# Altium schematic sheet 12-5V Buck Converter.SchDoc
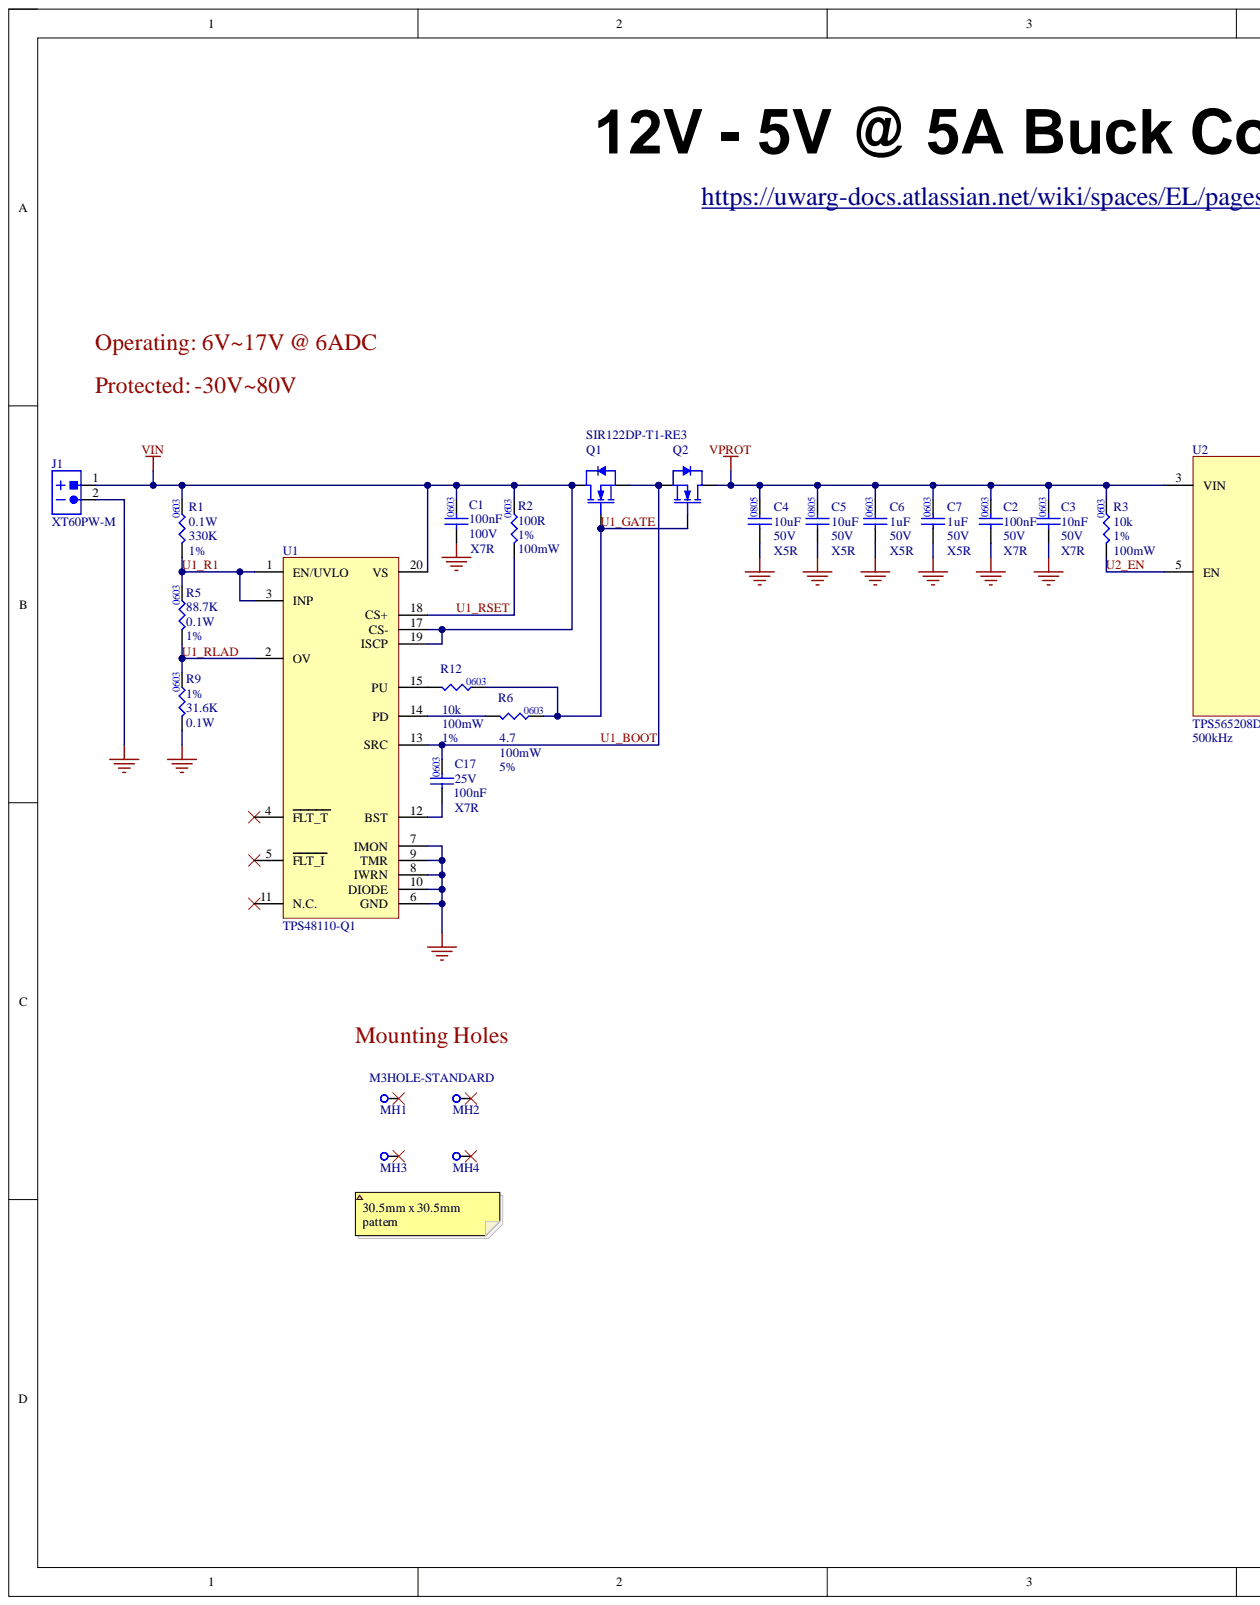
[diagram p0: 12-5V Buck Converter.SchDoc, left]
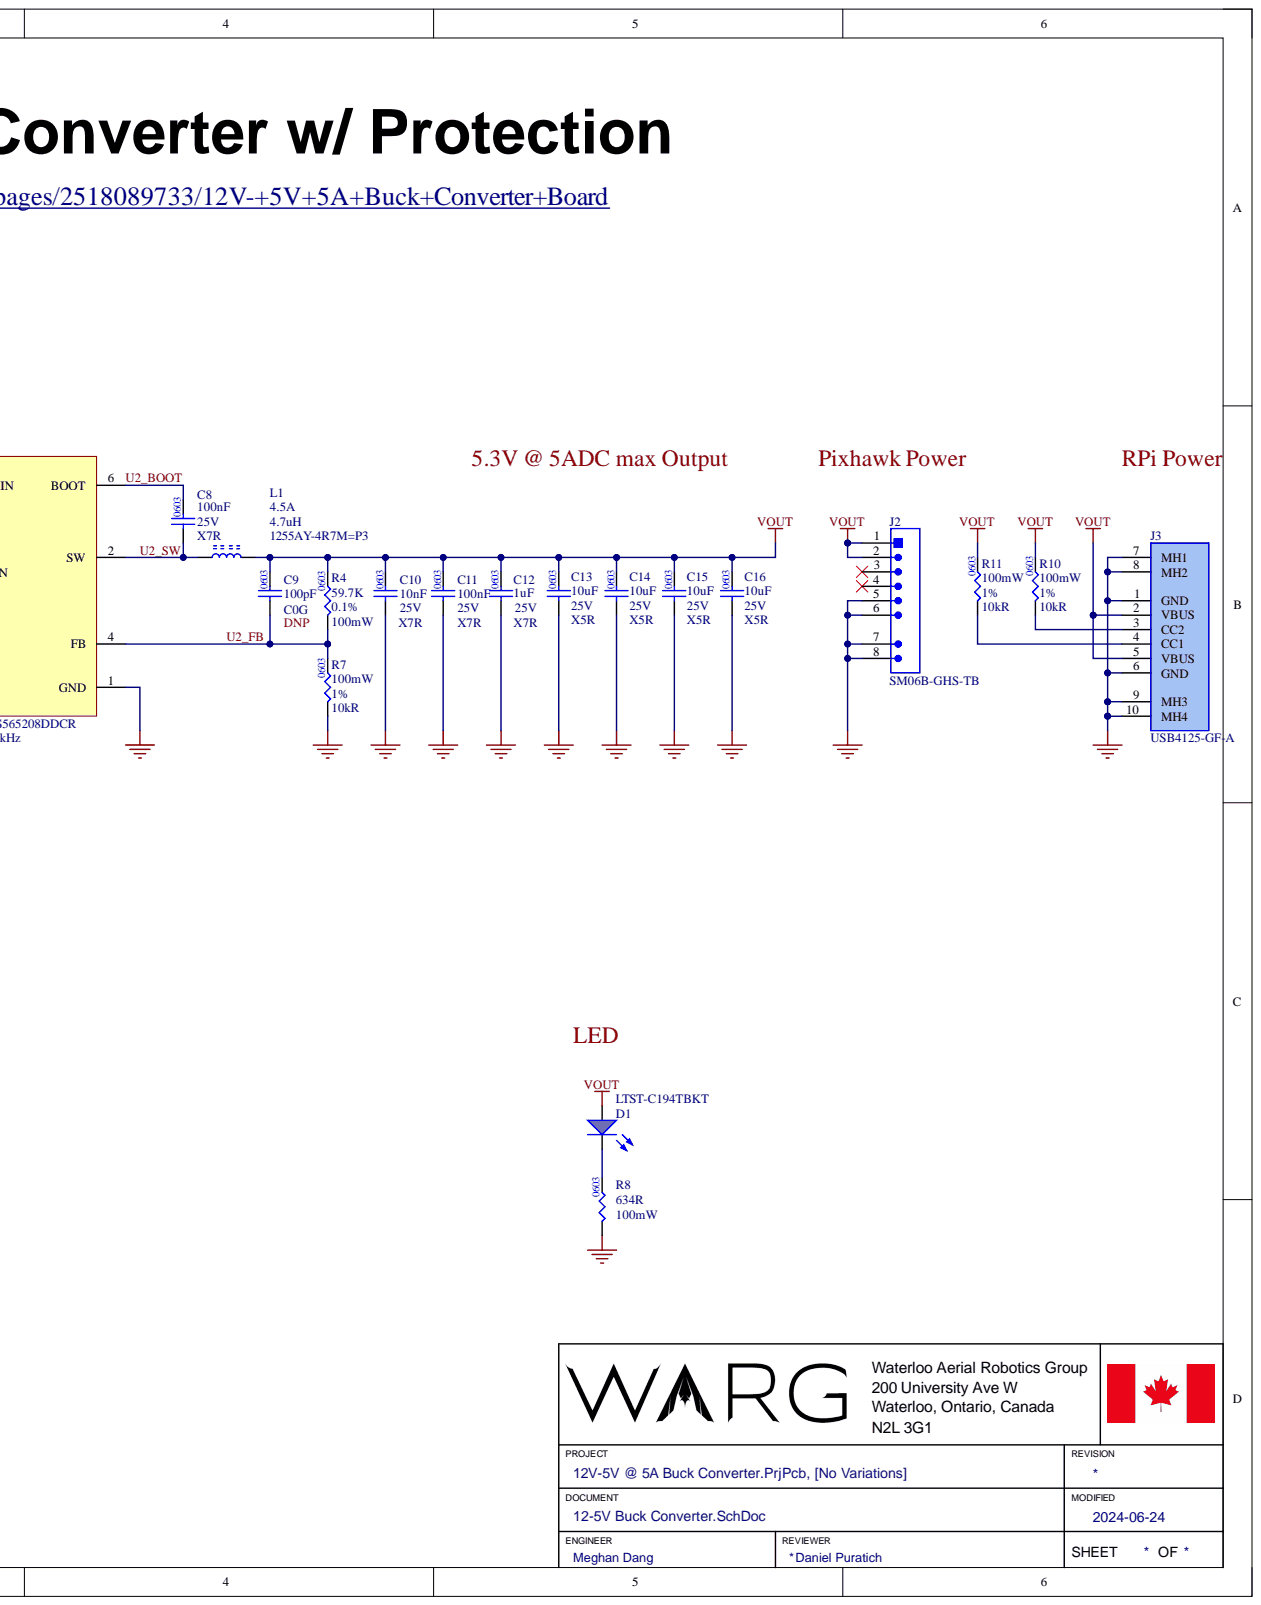
[diagram p1: 12-5V Buck Converter.SchDoc, right]

## Parts
C1  value 100nF  Case/Package: 0603  Dielectric: X7R  Rated DC Voltage (URdc): 100V  [.CAP_0603-Footprint-1]  (hidden comment: CC0603KRX7R0BB104)
C2  Capacitance: 100nF  Dielectric: X7R  Voltage Rating: 50V  [.CAP_0603-Footprint-1]  (hidden comment: CGA3E2X7R1H104K080AA)
C3  Capacitance: 10nF  Case Code (Imperial): 0603  Dielectric: X7R  Voltage: 50V  [.CAP_0603-Footprint-1]  (hidden comment: GCM188R71H103KA37D)
C4  value 10uF  Capacitance: 10uF  Dielectric: X5R  Package: 0805  Voltage: 50V  [.CAP_0805-Footprint-1]  (hidden comment: GRM21BR61H106KE43L)
C5  value 10uF  Capacitance: 10uF  Dielectric: X5R  Package: 0805  Voltage: 50V  [.CAP_0805-Footprint-1]  (hidden comment: GRM21BR61H106KE43L)
C6  Capacitance: 1uF  Case/Package: 0603  Dielectric: X5R  Voltage Rating: 50V  [.CAP_0603-Footprint-1]  (hidden comment: GCM188R71E102KA37D)
C7  Capacitance: 1uF  Case/Package: 0603  Dielectric: X5R  Voltage Rating: 50V  [.CAP_0603-Footprint-1]  (hidden comment: GCM188R71E102KA37D)
C8  Capacitance: 100nF  Case/Package: 0603  Dielectric: X7R  Voltage Rating: 25V  [CAP0603]  (hidden comment: CL10B104KA8NNNC)
C9  value 100pF  Case/Package: 0603  Temperature Characteristics Code: C0G  [.CAP_0603-Footprint-1]  (hidden comment: GCM1885C2A101JA16D)
C10  Capacitance: 10nF  Package: 0603  Temperature Coefficient: X7R  Voltage Rating: 25V  [.CAP_0603-Footprint-1]  (hidden comment: CC0603KRX7R8BB103)
C11  Capacitance: 100nF  Case/Package: 0603  Dielectric: X7R  Voltage Rating: 25V  [.CAP_0603-Footprint-1]  (hidden comment: CL10B104KA8NNNC)
C12  Capacitance: 1uF  Temperature Coefficient: X7R  Voltage Rating: 25V  [.CAP_0603-Footprint-1]  (hidden comment: GCM188R71E105KA64D)
C13  value 10uF  Case/Package: 0603  Temperature Coefficient: X5R  Voltage Rating: 25V  [.CAP_0603-Footprint-1]  (hidden comment: GRM188R61E106KA73J)
C14  value 10uF  Case/Package: 0603  Temperature Coefficient: X5R  Voltage Rating: 25V  [.CAP_0603-Footprint-1]  (hidden comment: GRM188R61E106KA73J)
C15  value 10uF  Case/Package: 0603  Temperature Coefficient: X5R  Voltage Rating: 25V  [.CAP_0603-Footprint-1]  (hidden comment: GRM188R61E106KA73J)
C16  value 10uF  Case/Package: 0603  Temperature Coefficient: X5R  Voltage Rating: 25V  [.CAP_0603-Footprint-1]  (hidden comment: GRM188R61E106KA73J)
C17  Capacitance: 100nF  Case/Package: 0603  Dielectric: X7R  Voltage Rating: 25V  [.CAP_0603-Footprint-1]  (hidden comment: CL10B104KA8NNNC)
D1  LTST-C194TBKT  [LTST-C194TBKT-Footprint-1]
J1  XT60PW-M  [XT60PW-M-Footprint-1]
J2  SM06B-GHS-TB  [SM06B-GHS-TB-Footprint-1]
J3  USB4125-GF-A  [USB4125-GF-A-Footprint-1]
L1  1255AY-4R7M=P3  Current Rating: 4.5A  Inductance: 4.7uH  [FP-DG6045C-MFG]
MH1  M3HOLE-STANDARD  [M3HOLE-STANDARD-Footprint-1]
MH2  M3HOLE-STANDARD  [M3HOLE-STANDARD-Footprint-1]
MH3  M3HOLE-STANDARD  [M3HOLE-STANDARD-Footprint-1]
MH4  M3HOLE-STANDARD  [M3HOLE-STANDARD-Footprint-1]
Q1  SIR122DP-T1-RE3  [SIR122DP-T1-RE3-Footprint-1]
Q2  SIR122DP-T1-RE3  [SIR122DP-T1-RE3-Footprint-1]
R1  Package: 0603  Power: 0.1W  Resistance: 330K  Tolerance: 1%  [.RES_0603-Footprint-1]  (hidden comment: RC0603FR-07330KL)
R2  Case Code (Imperial): 0603  Max Power Dissipation: 100mW  Resistance: 100R  Resistance Tolerance: 1%  [.RES_0603-Footprint-1]  (hidden comment: RC0603FR-07100RL)
R3  Rated Power Dissipation (P): 100mW  Resistance: 10k  Tolerance: 1%  [RES0603]  (hidden comment: AC0603FR-1310KL)
R4  Power Rating: 100mW  Resistance: 59.7K  Tolerance: 0.1%  [.RES_0603-Footprint-1]  (hidden comment: RT0603BRD0759K7L)
R5  Package: 0603  Power: 0.1W  Resistance: 88.7K  Tolerance: 1%  [.RES_0603-Footprint-1]  (hidden comment: RC0603FR-0788K7L)
R6  Package: 0603  Power: 100mW  Resistance: 4.7  [.RES_0603-Footprint-1]  (hidden comment: RC0603JR-074R7L)
R7  Rated Power Dissipation (P): 100mW  Resistance: 10kR  Tolerance: 1%  [.RES_0603-Footprint-1]  (hidden comment: AC0603FR-1310KL)
R8  value 634R  Case/Package: 0603  Power: 100mW  [.RES_0603-Footprint-1]  (hidden comment: RC0603FR-07634RL)
R9  Package: 0603  Power: 0.1W  Resistance: 31.6K  Tolerance: 1%  [.RES_0603-Footprint-1]  (hidden comment: RC0603FR-0731K6L)
R10  Rated Power Dissipation (P): 100mW  Resistance: 10kR  Tolerance: 1%  [.RES_0603-Footprint-1]  (hidden comment: AC0603FR-1310KL)
R11  Rated Power Dissipation (P): 100mW  Resistance: 10kR  Tolerance: 1%  [.RES_0603-Footprint-1]  (hidden comment: AC0603FR-1310KL)
R12  Resistance: 10k  [RES0603]  (hidden comment: AC0603FR-1310KL)
U1  TPS48110-Q1  [TPS48110-Q1-Footprint-1]
U2  TPS565208DDCR  Switching Frequency: 500kHz  [TPS565208DDCR-Footprint-1]

## Nets
GND: C1.1 C2.1 C3.1 C4.1 C5.1 C6.1 C7.1 C10.1 C11.1 C12.1 C13.1 C14.1 C15.1 C16.1 J1.2 J2.5 J2.6 J2.7 J2.8 J3.1(GND) J3.6(GND) J3.7(MH1) J3.8(MH2) J3.9(MH3) J3.10(MH4) R7.1 R8.1 R9.1 U1.6(GND) U1.7(IMON) U1.8(IWRN) U1.9(TMR) U1.10(DIODE) U2.1(GND)
VIN: C1.2 J1.1 Q1.D R1.2 R2.2 U1.17(CS-) U1.19(ISCP) U1.20(VS)
VOUT: C9.2 C10.2 C11.2 C12.2 C13.2 C14.2 C15.2 C16.2 D1.1 J2.1 J2.2 J3.2(VBUS) J3.5(VBUS) L1.2 R4.2 R10.2 R11.2
VPROT: C2.2 C3.2 C4.2 C5.2 C6.2 C7.2 Q2.D R3.2 U2.3(VIN)
U1_BOOT: C17.2 Q1.S Q2.S U1.13(SRC)
U1_GATE: Q1.G Q2.G R6.2 R12.2
U1_R1: R1.1 R5.2 U1.1(EN/UVLO) U1.3(INP)
U1_RLAD: R5.1 R9.2 U1.2(OV)
U1_RSET: R2.1 U1.18(CS+)
U2_BOOT: C8.2 U2.6(BOOT)
U2_EN: R3.1 U2.5(EN)
U2_FB: C9.1 R4.1 R7.2 U2.4(FB)
U2_SW: C8.1 L1.1 U2.2(SW)
NetC17_1: C17.1 U1.12(BST)
NetD1_2: D1.2 R8.2
NetJ3_3: J3.3(CC2) R10.1
NetJ3_4: J3.4(CC1) R11.1
NetR6_1: R6.1 U1.14(PD)
NetR12_1: R12.1 U1.15(PU)

Not connected: J2 3 4; MH1 1; MH2 1; MH3 1; MH4 1; U1 4(FLT_T) 5(FLT_I) 11(N.C.)

## Library data of the parts
C1 = CMP-00249-2: CAP CER 0.1UF 100V X7R 0603; Component Kind: Standard; Library Name: WARG
C2 = CMP-00227-3: CAP CER 0.1UF 50V X7R 0603; Component Kind: Standard; Library Name: WARG
C3 = CMP-00050-2: Cap Ceramic 0.01uF 50V X7R 10% SMD 0603 125C Automotive T/R; Case Code (Metric): 1608; Case/Package: 0603; Component Kind: Standard; Construction: Flat; Depth: 0.8mm; Height: 0.8mm; Lead Free: Lead Free; Length: 1.6mm; Max Operating Temperature: 125°C; Min Operating Temperature: -55°C; Mount: Surface Mount; Package Quantity: 1; Packaging: Tape and Reel; Radiation Hardening: No; Ratings: AEC-Q200; Reach SVHC Compliant: Yes; RoHS Compliant: Yes; Series: GCM; Termination: SMD/SMT; Thickness: 0.035inch; Tolerance: 10%; Voltage Rating: 50V; Voltage Rating (DC): 50V; Width: 0.031inch; Library Name: WARG
C4 C5 = CMP-001-00009-4: CAP CER 10uF 50V X5R 0805; Component Kind: Standard; Voltage Rating: 50V; Library Name: WARG
C6 C7 = CMP-00096-2: CAP CER 1uF 50V X5R 10% 0603; Component Kind: Standard; Mount: Surface Mount; Tolerance: 10%; Library Name: WARG
C8 = CMP-00057-1: CAP CER 0.1UF 25V X7R 0603; Tolerance: 10%; Component Kind: Standard; Value: 0.1uF; Library Name: WARG
C9 = CMP-001-00085-1: CAP CER 100PF 100V C0G/NP0 0603; Capacitor Type: Ceramic; Multilayer: Yes; Reference Standard: AEC-Q200; Tolerance  (fill in as +-): 5%; Component Kind: Standard; Dielectric Material: Ceramic; DNP: DNP; Height: 0.9mm; JESD-609 Code: e3; Length: 1.6mm; Max Operating Temperature: 125°C; Min Operating Temperature: -55°C; Mounting Technology: Surface Mount; Package Shape: Rectangular; Package Style: SMTMeter; Packaging: Tape and Reel; Pins: 2; Rated DC Voltage (URdc): 100V; Reach SVHC Compliant: Yes; RoHS Compliant: Yes; Terminal Finish: Nickel; Termination: Wraparound; Tolerance (fill in as +-): 5%; Width: 0.8mm; Library Name: WARG
C10 = CMP-00217-1: CAP CER 10nF 25V X7R 0603; Component Kind: Standard; Library Name: WARG
C11 C17 = CMP-00057-2: CAP CER 0.1UF 25V X7R 0603; Tolerance: 10%; Component Kind: Standard; Library Name: WARG
C12 = CMP-00240-2: CAP CER 1UF 25V X7R 0603; Tolerance: 10%; Component Kind: Standard; Mounting Technology: Surface Mount; Library Name: WARG
C13-C16 = CMP-00056-4: CAP CER 10UF 25V X5R 0603; Component Kind: Standard; Library Name: WARG
D1 = CMP-00017-2: LED BLUE CLEAR 0603 SMD; Dominant Wavelength: 473nm; Forward Current: 20mA; Forward Voltage: 2.8V; Lens Color: Colorless; Lens Size: 0.8mm; Lens Style: Flat,Diffused,Colorless,Rectangular; Luminous Intensity: 15mcd; Number of Elements: 1; Power Dissipation: 76mW; Reverse Voltage: 5V; Test Current: 5mA; Viewing Angle: 130°; Voltage: 29V; Case Code (Imperial): 0603; Case Code (Metric): 1608; Case/Package: 0603; Color: Blue; Component Kind: Standard; Depth: 0.8mm; Height: 0.65mm; Illumination Color: Blue; Lead Free: Lead Free; Length: 1.6mm; Lens Transparency: Clear; Max Operating Temperature: 80°C; Min Operating Temperature: -20°C; Mount: Surface Mount; Number of LEDs: 1; Number of Pins: 2; Package Quantity: 5000; Packaging: Tape and Reel; Radiation Hardening: No; RoHS Compliant: Yes; Series: -; Width: 0.8mm; Library Name: WARG
J1 = CMP-00007-11: Board Mount XT60PW-M 2 Pin Male Connector; Manufacturer: TME; Component Kind: Standard; Library Name: WARG
J2 = CMP-00248-1: CONN HEADER SMD R/A 6POS 1.25MM; Component Kind: Standard; Library Name: WARG
J3 = CMP-0024878-4: CONN RCPT TYPE C 6P SMD RA; Component Kind: Standard; Library Name: WARG
L1 = CMP-006-00006-6: FIXED IND 4.7UH 4A 23 MOHM SMD; Core Material: Ferrite; DC Resistance (DCR): 27.6mR; Tolerance: 20%; Component Kind: Standard; Max DC Current: 4A; Mount: Surface Mount; Library Name: WARG
MH1-MH4 = CMP-00005-4: Standard M3 Mounting hole as defined by mech team, see confluence for reasonning; Hole Diam: 3.4; Plating Diam: 6; Component Kind: Standard; Library Name: WARG
Q1 Q2 = CMP-00223-4: MOSFET N-CH 80V 16.7A/59.6A PPAK; Component Kind: Standard; Library Name: WARG
R1 = CMP-00232-2: RES 330K OHM 1% 1/10W 0603; Component Kind: Standard; Library Name: WARG
R2 = CMP-00022-3: RES 100 OHM 1% 1/10W 0603; Temperature Coefficient: 100ppm/°C; Voltage Rating: 50V; Case Code (Metric): 1608; Case/Package: 0603; Component Kind: Standard; Construction: Rectangular; Depth: 0.8mm; Features: Moisture Resistant; Height: 0.45mm; Lead Free: Lead Free; Length: 1.6mm; Max Operating Temperature: 155°C; Military Standard: Not; Min Operating Temperature: -55°C; Number of Pins: 2; Number of Terminations: 2; Packaging: Tape and Reel; Power Rating: 100mW; REACH SVHC: Unknown; Resistor Type: Thick Film; RoHS Compliant: Yes; Series: RC; Size Code: 0603; Technology: THICK FILM; Termination: SMD/SMT; Tolerance: 1%; Voltage Rating (DC): 50V; Width: 0.8mm; Library Name: WARG
R3 = CMP-009-00054-1: RES 10K OHM 1% 1/10W 0603; Rated Temperature: 70°C; Working Voltage: 75V; Component Kind: Standard; Mount: Surface Mount; Library Name: WARG
R4 = CMP-00244-2: RES SMD 59.7KOHM 0.1% 1/10W 0603; Component Kind: Standard; Library Name: WARG
R5 = CMP-00231-2: RES 88.7K OHM 1% 1/10W 0603; Component Kind: Standard; Library Name: WARG
R6 = CMP-009-00082-1: RES 4.7 OHM 5% 1/10W 0603; Component Kind: Standard; Tolerance: 5%; Library Name: WARG
R7 R10 R11 = CMP-009-00054-2: RES 10K OHM 1% 1/10W 0603; Rated Temperature: 70°C; Working Voltage: 75V; Component Kind: Standard; Mount: Surface Mount; Library Name: WARG
R8 = CMP-00251-1: RES 634 OHM 1% 1/10W 0603; Component Kind: Standard; Tolerance: 1%; Library Name: WARG
R9 = CMP-00230-2: RES 31.6K OHM 1% 1/10W 0603; Component Kind: Standard; Library Name: WARG
R12 = CMP-009-00054-1: RES 10K OHM 1% 1/10W 0603; Rated Power Dissipation (P): 100mW; Rated Temperature: 70°C; Working Voltage: 75V; Component Kind: Standard; Mount: Surface Mount; Tolerance: 1%; Library Name: WARG
U1 = CMP-00221-9: AUTOMOTIVE 3.5-V TO 80-V HIGH SI; Component Kind: Standard; Library Name: WARG
U2 = CMP-00229-2: IC REG BUCK ADJ 5A SOT23; Component Kind: Standard; Library Name: WARG
